# Altium schematic sheet P09_LEDS_BUTTONS_JUMPER.SchDoc(P09_LEDS_BUTTONS_JUMPER)
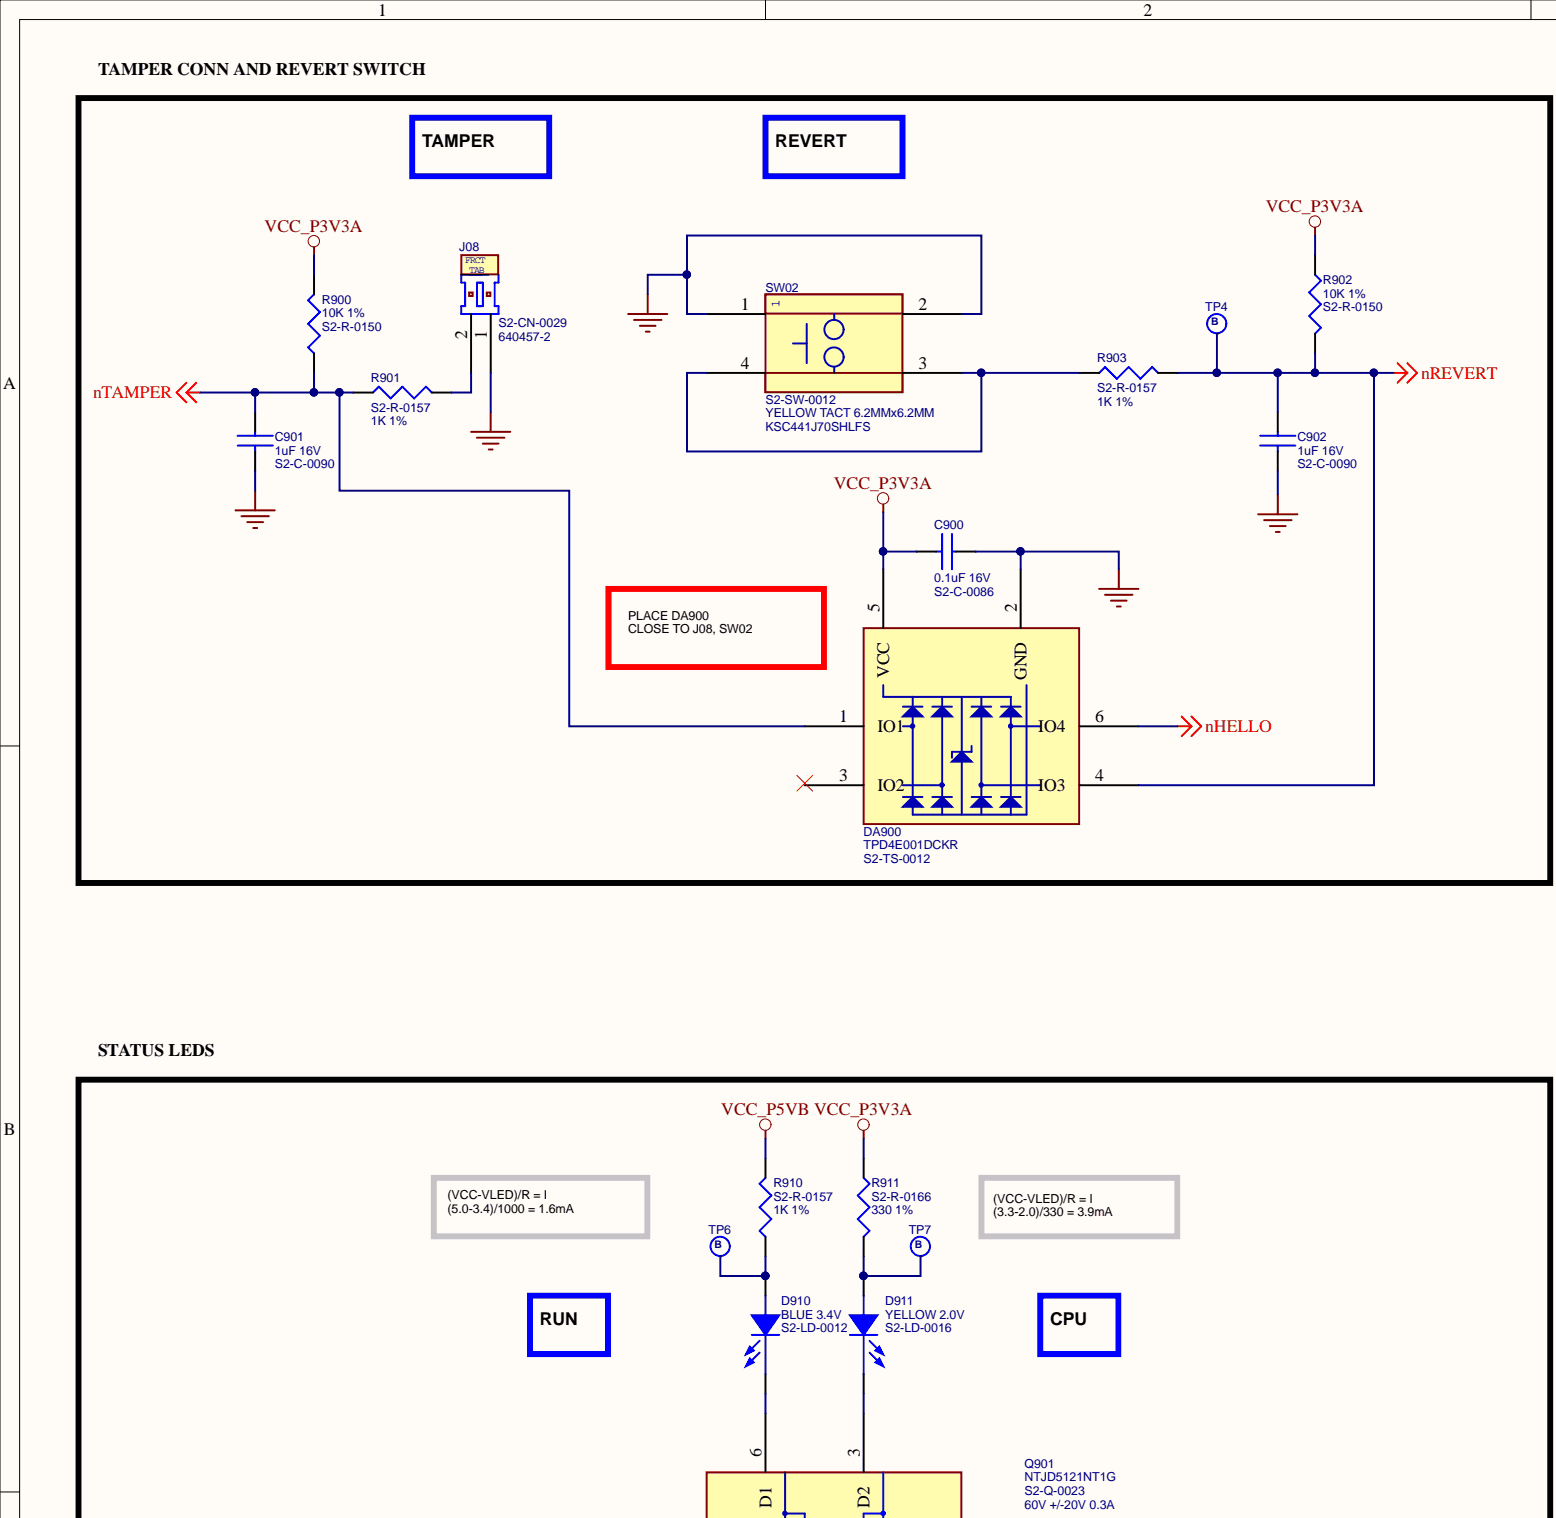
[diagram p0: P09_LEDS_BUTTONS_JUMPER.SchDoc, top left]
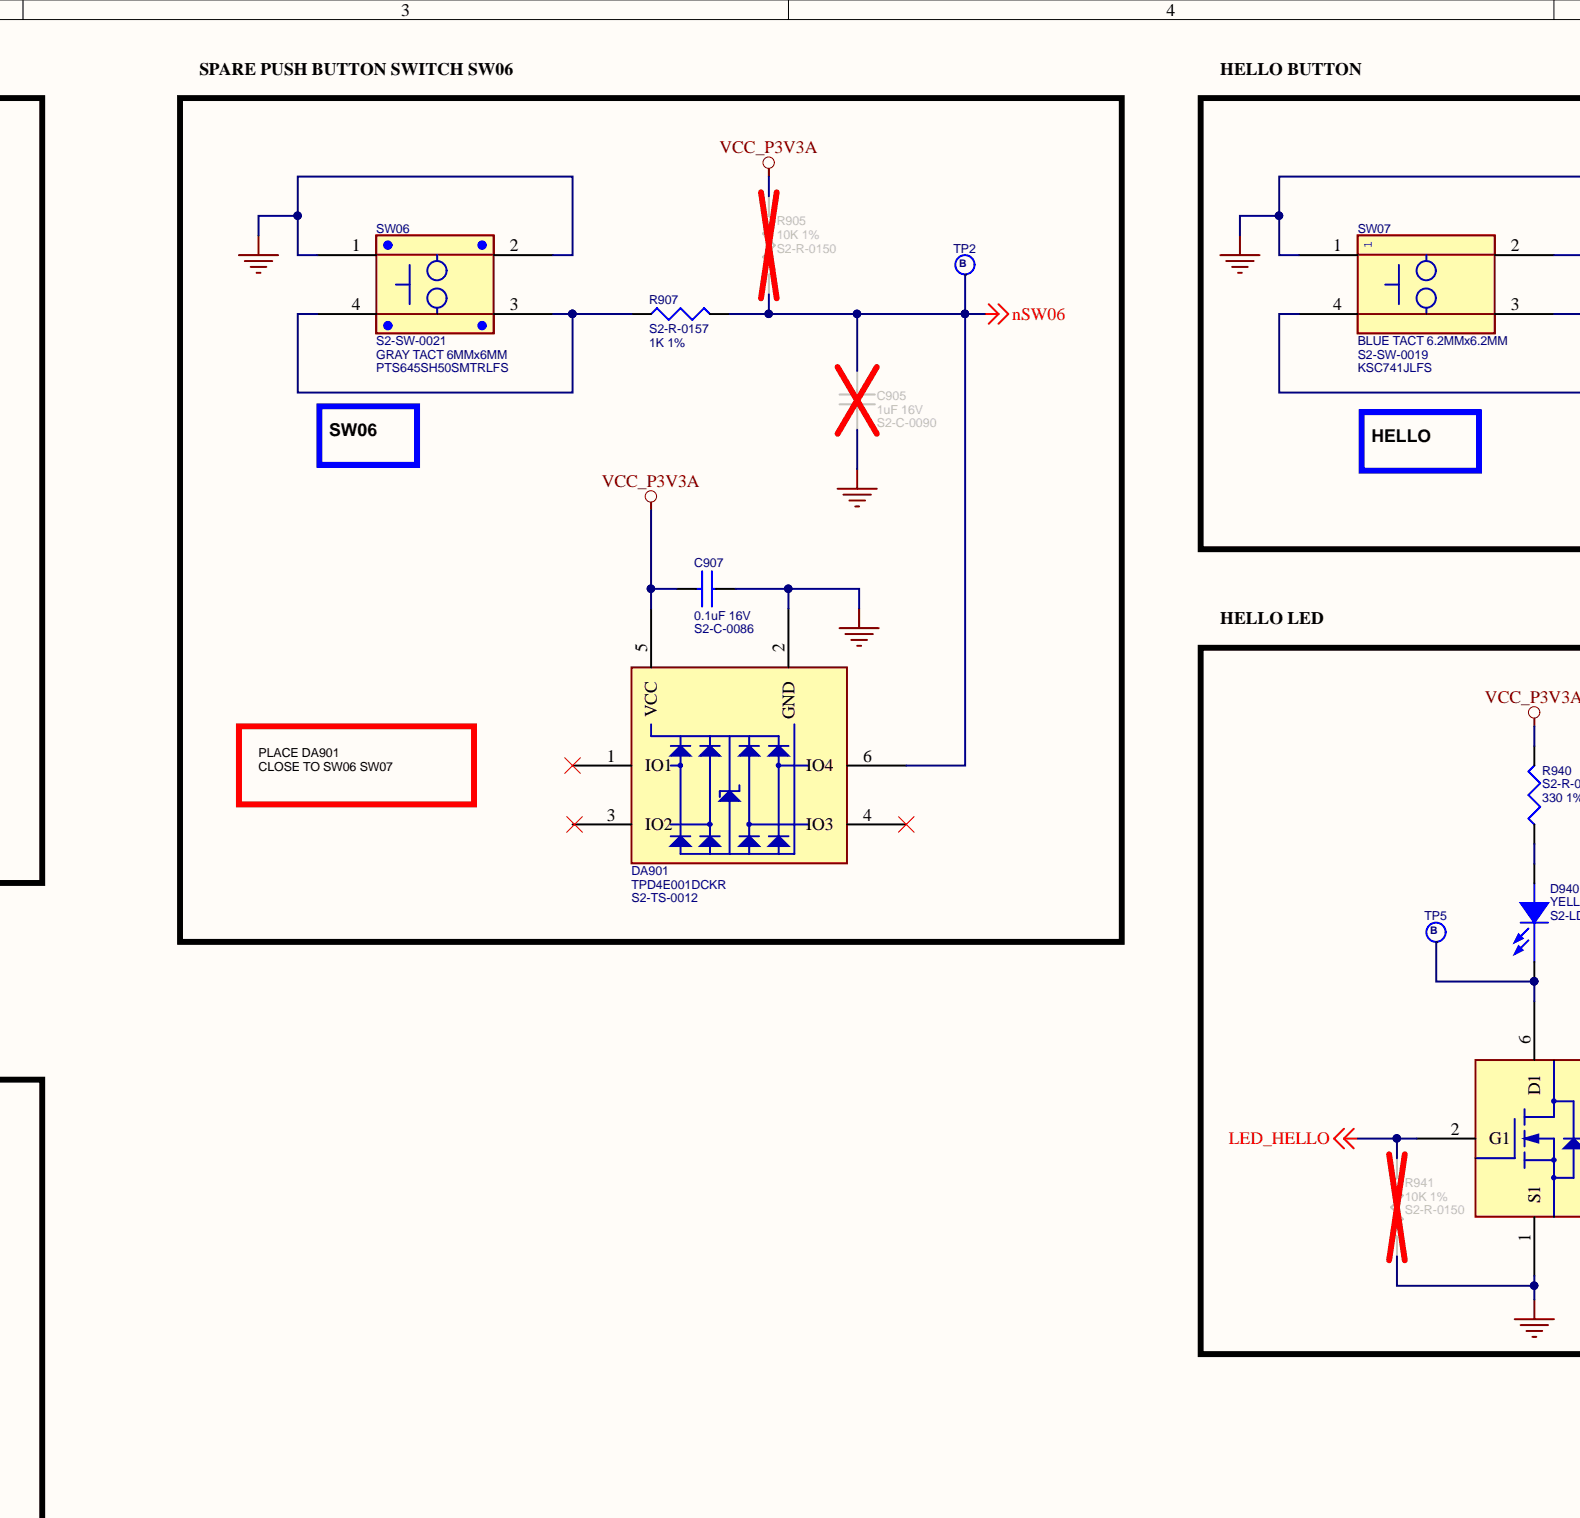
[diagram p1: P09_LEDS_BUTTONS_JUMPER.SchDoc, top centre]
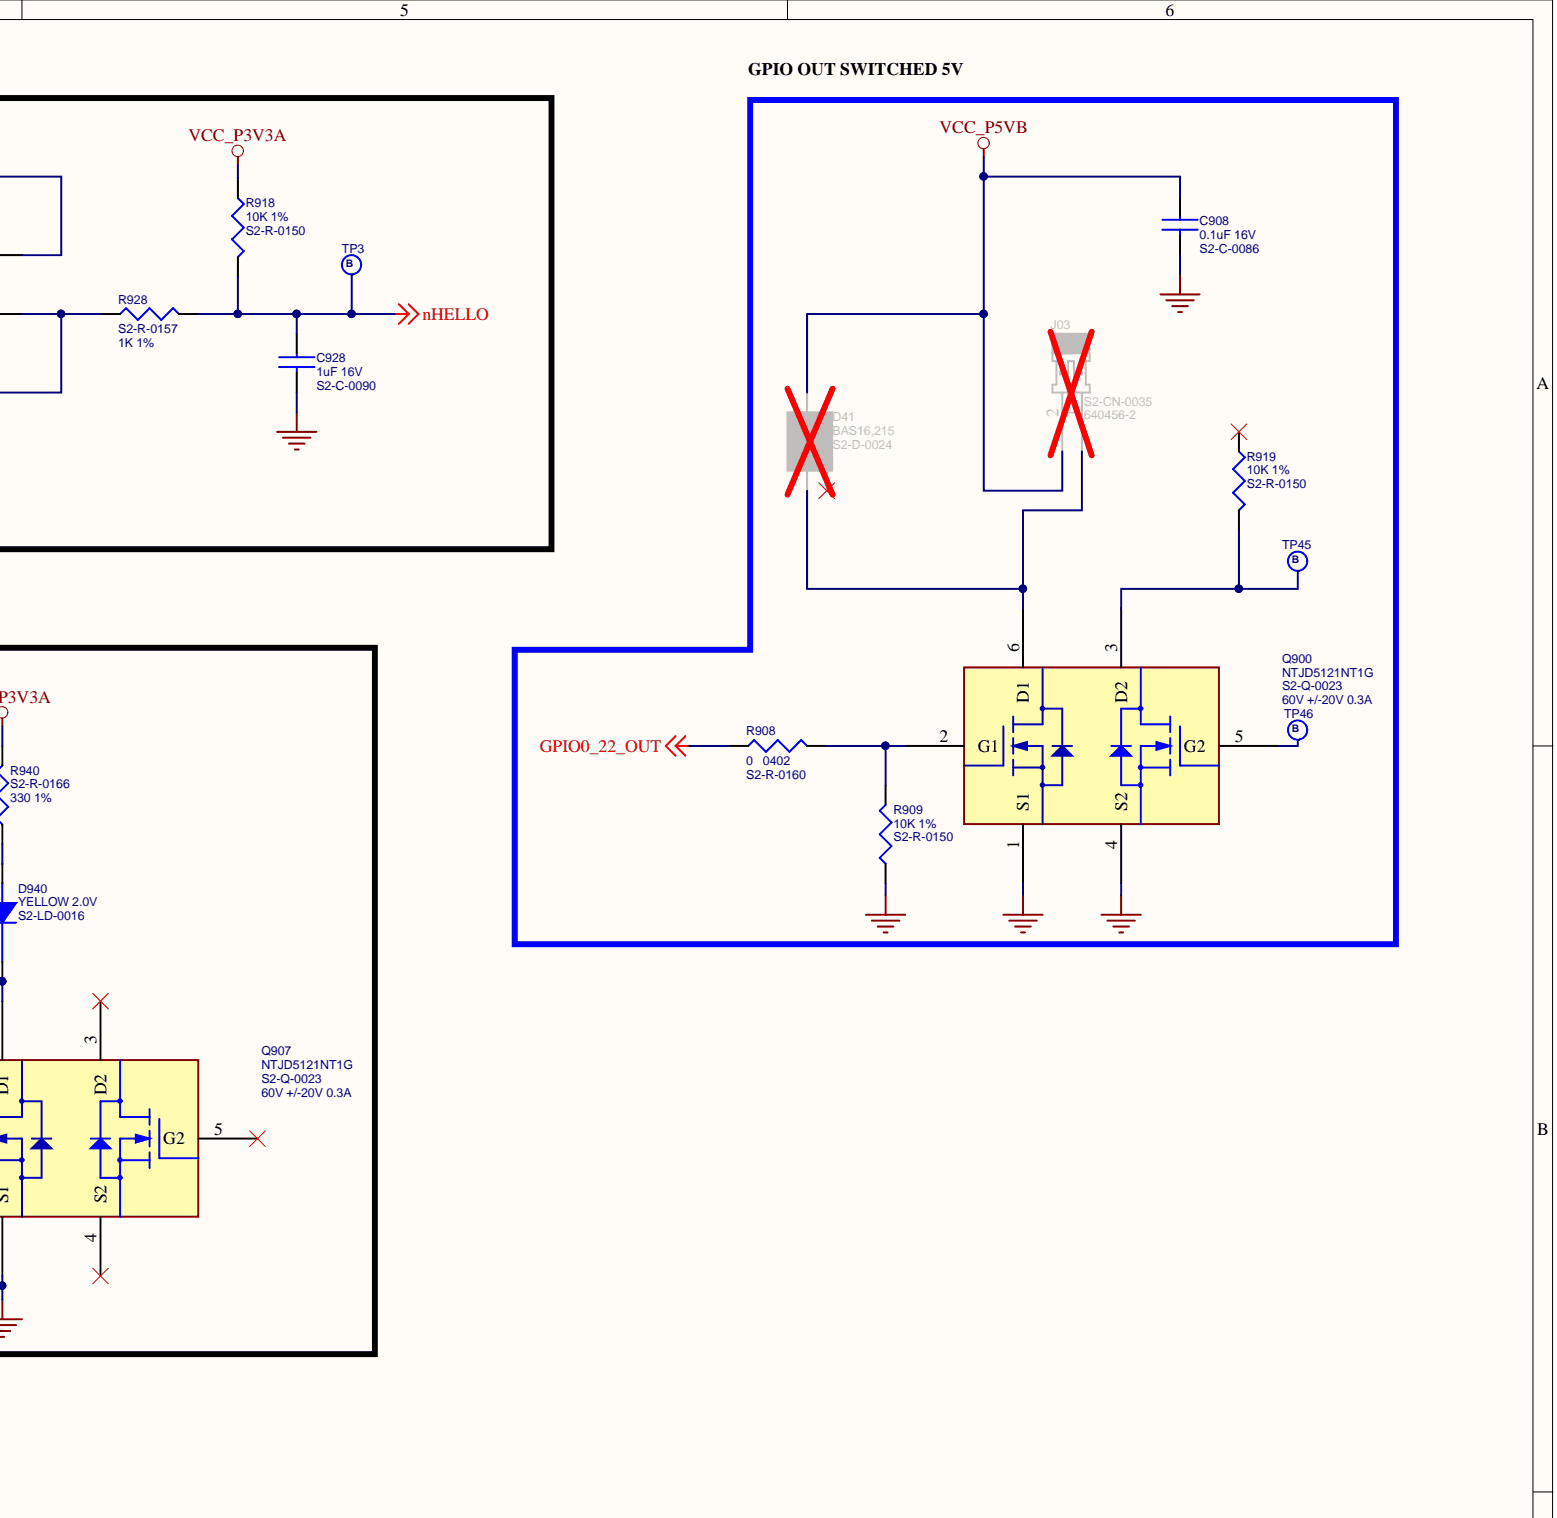
[diagram p2: P09_LEDS_BUTTONS_JUMPER.SchDoc, top right]
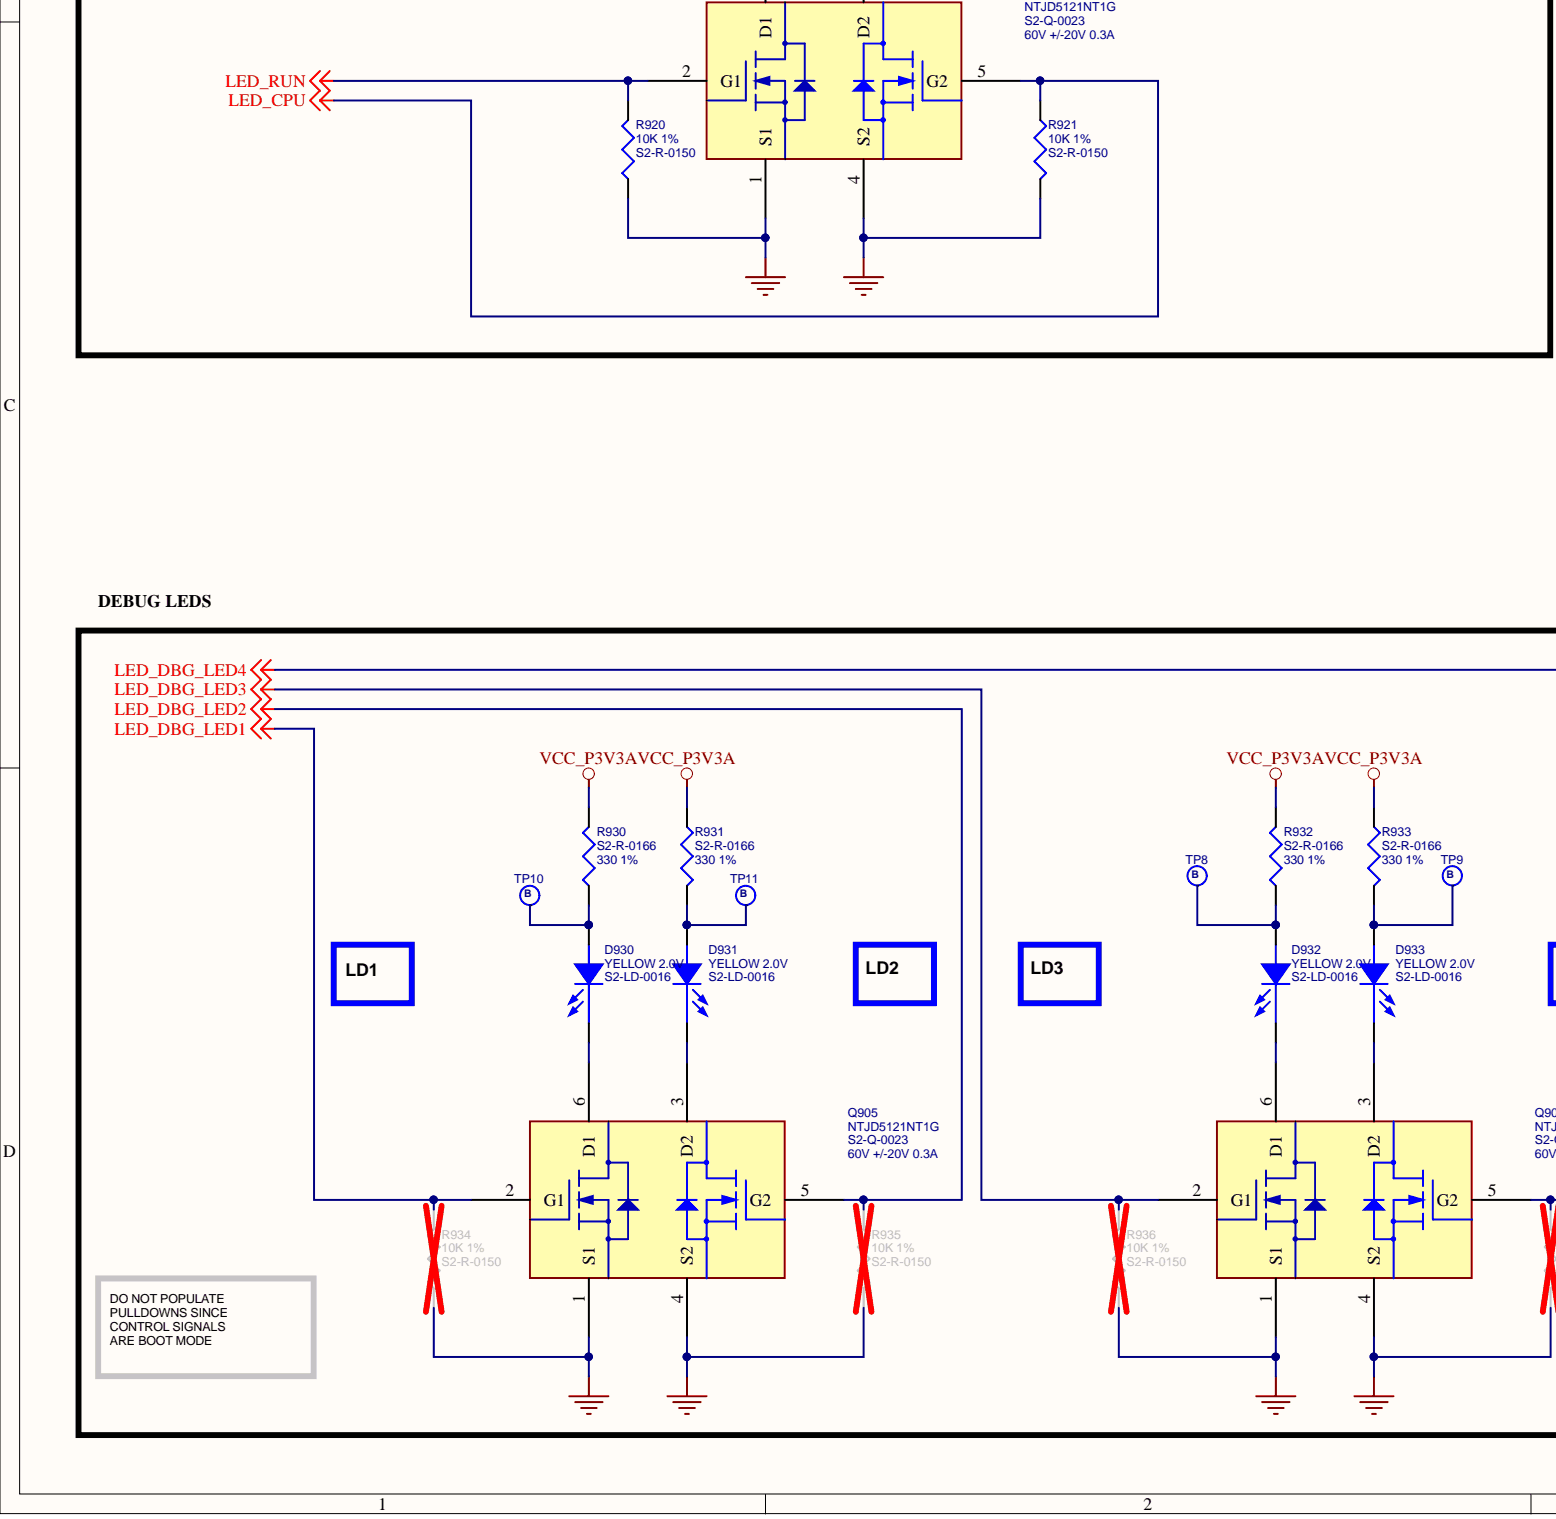
[diagram p3: P09_LEDS_BUTTONS_JUMPER.SchDoc, bottom left]
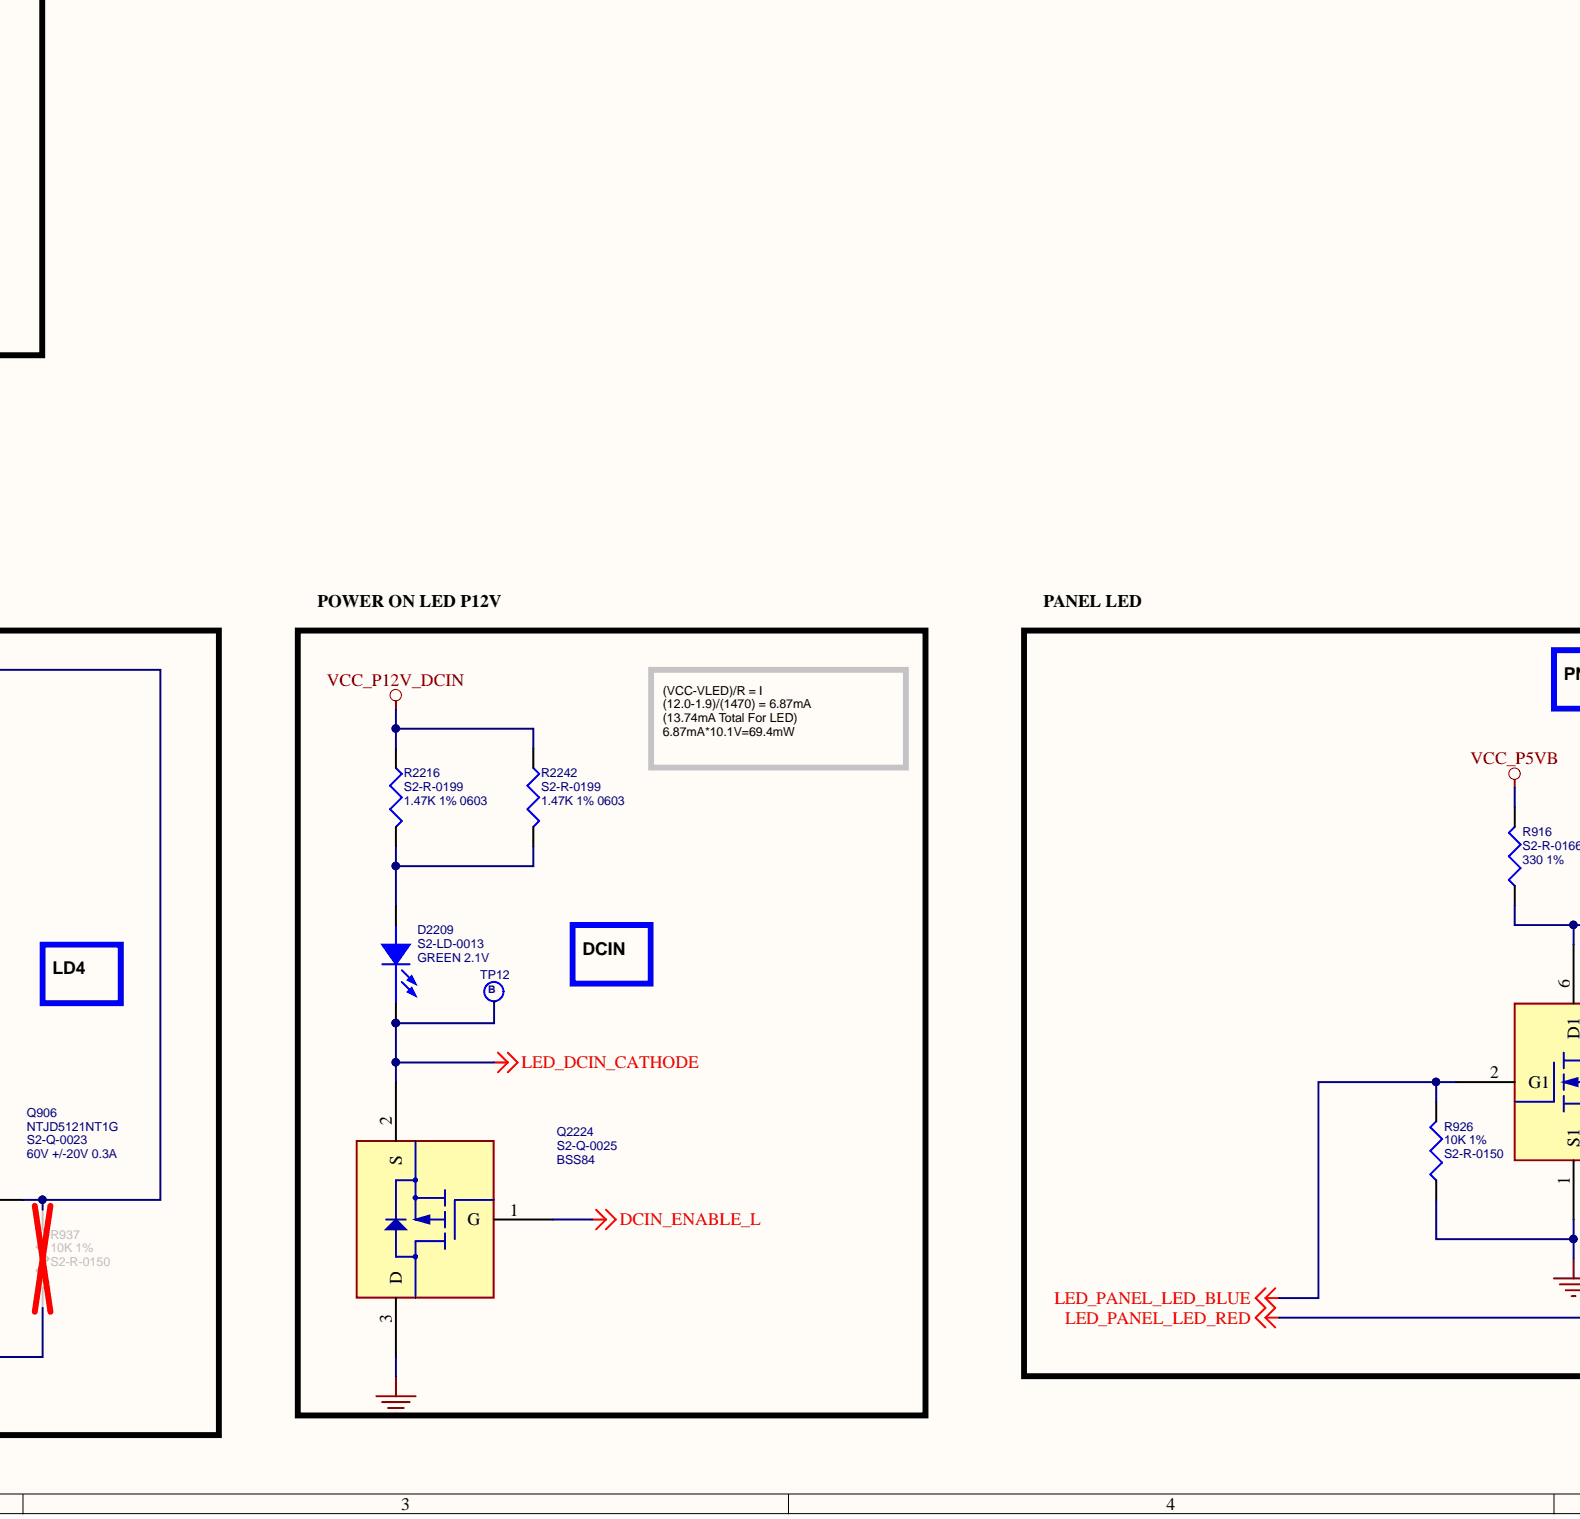
[diagram p4: P09_LEDS_BUTTONS_JUMPER.SchDoc, bottom centre]
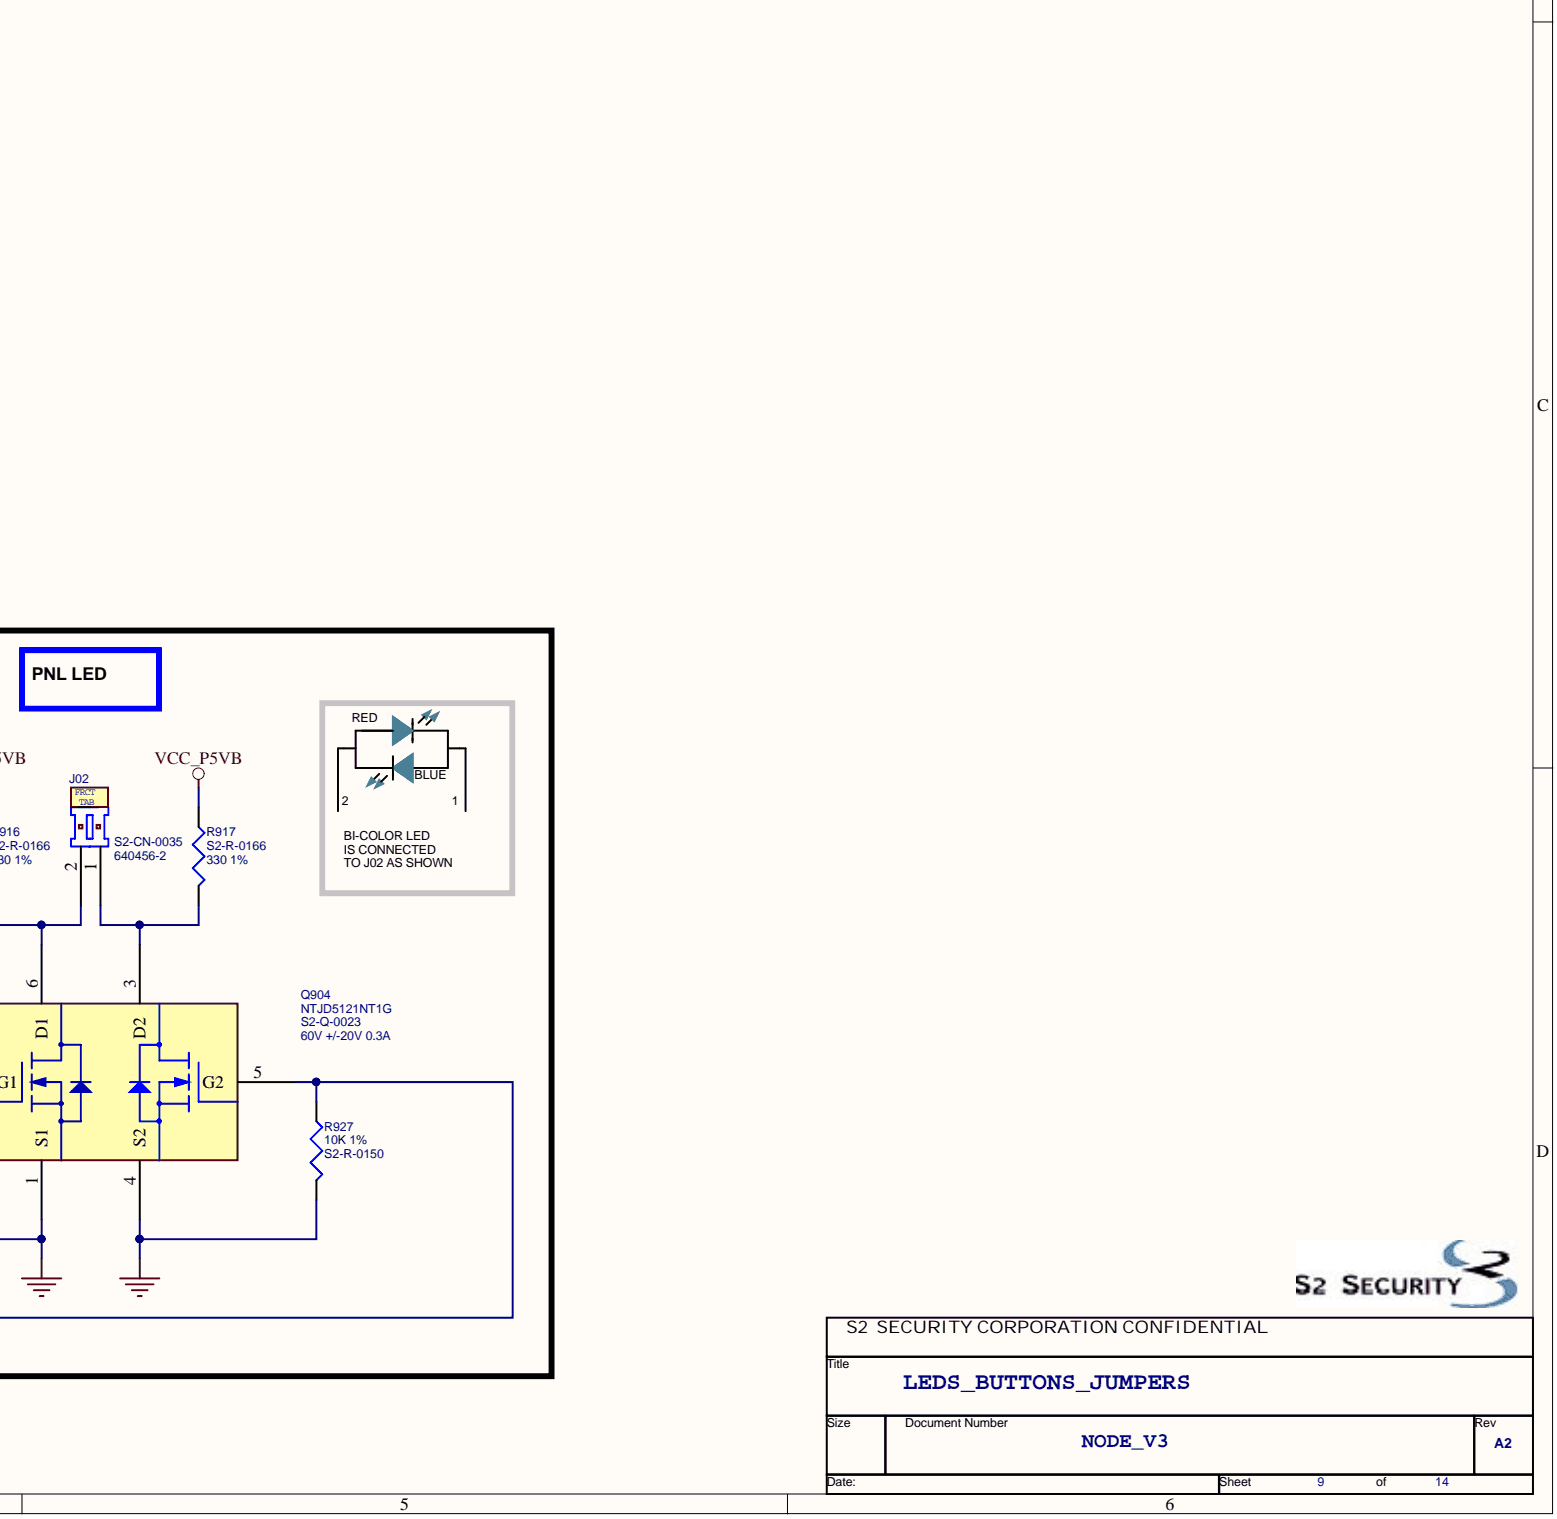
[diagram p5: P09_LEDS_BUTTONS_JUMPER.SchDoc, bottom right]

## Parts
C900  DESC: 0.1uF 16V  [CAPC1005X55N]  (hidden comment: C_0U1_16V_0402)
C901  S2_PN: S2-C-0090  DESC: 1uF 16V  [CAPC1005X55N]  (hidden comment: C_1U_16V_0402)
C902  S2_PN: S2-C-0090  DESC: 1uF 16V  [CAPC1005X55N]  (hidden comment: C_1U_16V_0402)
C905  S2_PN: S2-C-0090  DESC: 1uF 16V  [CAPC1005X55N]  (hidden comment: C_1U_16V_0402)
C907  DESC: 0.1uF 16V  [CAPC1005X55N]  (hidden comment: C_0U1_16V_0402)
C908  S2_PN: S2-C-0086  DESC: 0.1uF 16V  [CAPC1005X55N]  (hidden comment: C_0U1_16V_0402)
C928  S2_PN: S2-C-0090  DESC: 1uF 16V  [CAPC1005X55N]  (hidden comment: C_1U_16V_0402)
D41  S2_PN: S2-D-0024  DESC: BAS16,215  [SOT95P310X140-3N]  (hidden comment: D_BAS16_SOT23)
D910  S2_PN: S2-LD-0012  ATTR: BLUE 3.4V  [LED_0805]  (hidden comment: LED_0805_BLUE)
D911  S2_PN: S2-LD-0016  ATTR: YELLOW 2.0V  [LED_0805]  (hidden comment: LED_0805_YELLOW)
D930  S2_PN: S2-LD-0016  [LED_0805]  (hidden comment: LED_0805_YELLOW)
D931  S2_PN: S2-LD-0016  ATTR: YELLOW 2.0V  [LED_0805]  (hidden comment: LED_0805_YELLOW)
D932  S2_PN: S2-LD-0016  [LED_0805]  (hidden comment: LED_0805_YELLOW)
D933  S2_PN: S2-LD-0016  ATTR: YELLOW 2.0V  [LED_0805]  (hidden comment: LED_0805_YELLOW)
D940  S2_PN: S2-LD-0016  ATTR: YELLOW 2.0V  [LED_0805]  (hidden comment: LED_0805_YELLOW)
D2209  S2_PN: S2-LD-0013  [LED_0805]  (hidden comment: LED_0805_GREEN)
DA900  S2_PN: S2-TS-0012  DESC: TPD4E001DCKR  [SOIC65Px210X110P-6N]  (hidden comment: DA_TPD4E001_ESD_QUAD)
DA901  S2_PN: S2-TS-0012  DESC: TPD4E001DCKR  [SOIC65Px210X110P-6N]  (hidden comment: DA_TPD4E001_ESD_QUAD)
J02  S2_PN: S2-CN-0035  PKG: 640456-2  [Conn_640456-2]  (hidden comment: CN_100_2POS_STR_FRICT_LOCK)
J03  S2_PN: S2-CN-0035  PKG: 640456-2  [Conn_640456-2]  (hidden comment: CN_100_2POS_STR_FRICT_LOCK)
J08  S2_PN: S2-CN-0029  PKG: 640457-2  [CONN_640457-2]  (hidden comment: CN_100_2POS_RTA_FRICT_LOCK)
Q900  S2_PN: S2-Q-0023  DESC: NTJD5121NT1G  [SOT65x210x140x110-6N]  (hidden comment: Q_DUAL_NFET_NTJD5121N)
Q901  S2_PN: S2-Q-0023  DESC: NTJD5121NT1G  ATTR2: 60V +/-20V 0.3A  [SOT65x210x140x110-6N]  (hidden comment: Q_DUAL_NFET_NTJD5121N)
Q904  S2_PN: S2-Q-0023  DESC: NTJD5121NT1G  ATTR2: 60V +/-20V 0.3A  [SOT65x210x140x110-6N]  (hidden comment: Q_DUAL_NFET_NTJD5121N)
Q905  S2_PN: S2-Q-0023  DESC: NTJD5121NT1G  ATTR2: 60V +/-20V 0.3A  [SOT65x210x140x110-6N]  (hidden comment: Q_DUAL_NFET_NTJD5121N)
Q906  S2_PN: S2-Q-0023  DESC: NTJD5121NT1G  ATTR2: 60V +/-20V 0.3A  [SOT65x210x140x110-6N]  (hidden comment: Q_DUAL_NFET_NTJD5121N)
Q907  S2_PN: S2-Q-0023  DESC: NTJD5121NT1G  ATTR2: 60V +/-20V 0.3A  [SOT65x210x140x110-6N]  (hidden comment: Q_DUAL_NFET_NTJD5121N)
Q2224  S2_PN: S2-Q-0025  DESC: BSS84  [SOT95P310X140-3N]  (hidden comment: Q_PFET_BSS84)
R900  S2_PN: S2-R-0150  DESC: 10K 1%  [RESC1005X40N]  (hidden comment: R_10K_0402)
R901  S2_PN: S2-R-0157  DESC: 1K 1%  [RESC1005X40N]  (hidden comment: R_1K_0402)
R902  S2_PN: S2-R-0150  DESC: 10K 1%  [RESC1005X40N]  (hidden comment: R_10K_0402)
R903  S2_PN: S2-R-0157  DESC: 1K 1%  [RESC1005X40N]  (hidden comment: R_1K_0402)
R905  S2_PN: S2-R-0150  DESC: 10K 1%  [RESC1005X40N]  (hidden comment: R_10K_0402)
R907  S2_PN: S2-R-0157  DESC: 1K 1%  [RESC1005X40N]  (hidden comment: R_1K_0402)
R908  S2_PN: S2-R-0160  DESC: 0   0402  [RESC1005X40N]  (hidden comment: R_0_0402)
R909  S2_PN: S2-R-0150  DESC: 10K 1%  [RESC1005X40N]  (hidden comment: R_10K_0402)
R910  S2_PN: S2-R-0157  DESC: 1K 1%  [RESC1005X40N]  (hidden comment: R_1K_0402)
R911  S2_PN: S2-R-0166  [RESC1005X40N]  (hidden comment: R_330_0402)
R916  S2_PN: S2-R-0166  DESC: 330 1%  [RESC1005X40N]  (hidden comment: R_330_0402)
R917  S2_PN: S2-R-0166  DESC: 330 1%  [RESC1005X40N]  (hidden comment: R_330_0402)
R918  S2_PN: S2-R-0150  DESC: 10K 1%  [RESC1005X40N]  (hidden comment: R_10K_0402)
R919  S2_PN: S2-R-0150  DESC: 10K 1%  [RESC1005X40N]  (hidden comment: R_10K_0402)
R920  S2_PN: S2-R-0150  DESC: 10K 1%  [RESC1005X40N]  (hidden comment: R_10K_0402)
R921  S2_PN: S2-R-0150  DESC: 10K 1%  [RESC1005X40N]  (hidden comment: R_10K_0402)
R926  S2_PN: S2-R-0150  DESC: 10K 1%  [RESC1005X40N]  (hidden comment: R_10K_0402)
R927  S2_PN: S2-R-0150  DESC: 10K 1%  [RESC1005X40N]  (hidden comment: R_10K_0402)
R928  S2_PN: S2-R-0157  DESC: 1K 1%  [RESC1005X40N]  (hidden comment: R_1K_0402)
R930  S2_PN: S2-R-0166  DESC: 330 1%  [RESC1005X40N]  (hidden comment: R_330_0402)
R931  S2_PN: S2-R-0166  [RESC1005X40N]  (hidden comment: R_330_0402)
R932  S2_PN: S2-R-0166  DESC: 330 1%  [RESC1005X40N]  (hidden comment: R_330_0402)
R933  S2_PN: S2-R-0166  DESC: 330 1%  [RESC1005X40N]  (hidden comment: R_330_0402)
R934  S2_PN: S2-R-0150  DESC: 10K 1%  [RESC1005X40N]  (hidden comment: R_10K_0402)
R935  S2_PN: S2-R-0150  DESC: 10K 1%  [RESC1005X40N]  (hidden comment: R_10K_0402)
R936  S2_PN: S2-R-0150  DESC: 10K 1%  [RESC1005X40N]  (hidden comment: R_10K_0402)
R937  S2_PN: S2-R-0150  DESC: 10K 1%  [RESC1005X40N]  (hidden comment: R_10K_0402)
R940  S2_PN: S2-R-0166  DESC: 330 1%  [RESC1005X40N]  (hidden comment: R_330_0402)
R941  S2_PN: S2-R-0150  DESC: 10K 1%  [RESC1005X40N]  (hidden comment: R_10K_0402)
R2216  S2_PN: S2-R-0199  DESC: 1.47K 1% 0603  [RESC1608X55N]  (hidden comment: R_1K47_0603)
R2242  S2_PN: S2-R-0199  DESC: 1.47K 1% 0603  [RESC1608X55N]  (hidden comment: R_1K47_0603)
SW02  S2_PN: S2-SW-0012  DESC: KSC441J70SHLFS  ATTR: YELLOW TACT 6.2MMx6.2MM  [SW_KSC441J70SHLFS]  (hidden comment: SW_KSC441J_YELLOW_SMT)
SW06  S2_PN: S2-SW-0021  ATTR2: PTS645SH50SMTRLFS  ATTR: GRAY TACT 6MMx6MM  [SW_PTS645SK43SMTRLFS]  (hidden comment: SW_PTS645_SMT_GRAY)
SW07  S2_PN: S2-SW-0019  ATTR2: KSC741JLFS  ATTR: BLUE TACT 6.2MMx6.2MM  [SW_KSC441J70SHLFS]  (hidden comment: SW_KSCJ_SMT_BLUE)
TP2  TP_SMT_TEST_POINT_BOTTOM  [TP35_SMT]  (value not drawn)
TP3  TP_SMT_TEST_POINT_BOTTOM  [TP35_SMT]  (value not drawn)
TP4  TP_SMT_TEST_POINT_BOTTOM  [TP35_SMT]  (value not drawn)
TP5  TP_SMT_TEST_POINT_BOTTOM  [TP35_SMT]  (value not drawn)
TP6  TP_SMT_TEST_POINT_BOTTOM  [TP35_SMT]  (value not drawn)
TP7  TP_SMT_TEST_POINT_BOTTOM  [TP35_SMT]  (value not drawn)
TP8  TP_SMT_TEST_POINT_BOTTOM  [TP35_SMT]  (value not drawn)
TP9  TP_SMT_TEST_POINT_BOTTOM  [TP35_SMT]  (value not drawn)
TP10  TP_SMT_TEST_POINT_BOTTOM  [TP35_SMT]  (value not drawn)
TP11  TP_SMT_TEST_POINT_BOTTOM  [TP35_SMT]  (value not drawn)
TP12  TP_SMT_TEST_POINT_BOTTOM  [TP35_SMT]  (value not drawn)
TP45  TP_SMT_TEST_POINT_BOTTOM  [TP35_SMT]  (value not drawn)
TP46  TP_SMT_TEST_POINT_BOTTOM  [TP35_SMT]  (value not drawn)

## Nets
DCIN_ENABLE_L: Q2224.1
GND: C900.1 C901.2 C902.2 C905.2 C907.1 C908.2 C928.2 DA900.2(GND) DA901.2(GND) J08.1 Q900.1(S1) Q900.4(S2) Q901.1(S1) Q901.4(S2) Q904.1(S1) Q904.4(S2) Q905.1(S1) Q905.4(S2) Q906.1(S1) Q906.4(S2) Q907.1(S1) Q2224.3 R909.2 R920.2 R921.2 R926.2 R927.2 R934.2 R935.2 R936.2 R937.2 R941.2 SW02.1 SW02.2 SW06.1 SW06.2 SW07.1 SW07.2
GPIO0_22_OUT: R908.1
LED_CPU: Q901.5(G2) R921.1
LED_DBG_LED1: Q905.2(G1) R934.1
LED_DBG_LED2: Q905.5(G2) R935.1
LED_DBG_LED3: Q906.2(G1) R936.1
LED_DBG_LED4: Q906.5(G2) R937.1
LED_DCIN_CATHODE: D2209.2 Q2224.2 TP12.1
LED_HELLO: Q907.2(G1) R941.1
LED_PANEL_LED_BLUE: Q904.2(G1) R926.1
LED_PANEL_LED_RED: Q904.5(G2) R927.1
LED_RUN: Q901.2(G1) R920.1
nHELLO: C928.1 DA900.6(IO4) R918.2 R928.2 TP3.1
nREVERT: C902.1 DA900.4(IO3) R902.2 R903.2 TP4.1
nSW06: C905.1 DA901.6(IO4) R905.2 R907.2 TP2.1
nTAMPER: C901.1 DA900.1(IO1) R900.2 R901.1
VCC_P3V3A: C900.2 C907.2 DA900.5(VCC) DA901.5(VCC) R900.1 R902.1 R905.1 R911.1 R918.1 R930.1 R931.1 R932.1 R933.1 R940.1
VCC_P5VB: C908.1 D41.3 J03.2 R910.1 R916.1 R917.1
VCC_P12V_DCIN: R2216.1 R2242.1
NetD41_1: D41.1 J03.1 Q900.6(D1)
NetD910_1: D910.1 R910.2 TP6.1
NetD910_2: D910.2 Q901.6(D1)
NetD911_1: D911.1 R911.2 TP7.1
NetD911_2: D911.2 Q901.3(D2)
NetD930_1: D930.1 R930.2 TP10.1
NetD930_2: D930.2 Q905.6(D1)
NetD931_1: D931.1 R931.2 TP11.1
NetD931_2: D931.2 Q905.3(D2)
NetD932_1: D932.1 R932.2 TP8.1
NetD932_2: D932.2 Q906.6(D1)
NetD933_1: D933.1 R933.2 TP9.1
NetD933_2: D933.2 Q906.3(D2)
NetD940_1: D940.1 R940.2
NetD940_2: D940.2 Q907.6(D1) TP5.1
NetD2209_1: D2209.1 R2216.2 R2242.2
NetJ02_1: J02.1 Q904.3(D2) R917.2
NetJ02_2: J02.2 Q904.6(D1) R916.2
NetJ08_2: J08.2 R901.2
NetQ900_2: Q900.2(G1) R908.2 R909.1
NetQ900_3: Q900.3(D2) R919.2 TP45.1
NetQ900_5: Q900.5(G2) TP46.1
NetR903_1: R903.1 SW02.3 SW02.4
NetR907_1: R907.1 SW06.3 SW06.4
NetR928_1: R928.1 SW07.3 SW07.4

Not connected: D41 2; DA900 3(IO2); DA901 1(IO1) 3(IO2) 4(IO3); Q907 3(D2) 4(S2) 5(G2); R919 1

## Library data of the parts
C900 C907 = C_0U1_16V_0402: S2_PN: S2-C-0086; PKG: CC0402IPC; ATTR: X7R 10%; Library Name: NODE_V2_M1.SCHLIB; Component Kind: Standard
C901 C902 C905 C928 = C_1U_16V_0402: PKG: CC0402IPC; ATTR: X5R 10%; Library Name: NODE_V2_M1.SCHLIB; Component Kind: Standard
C908 = C_0U1_16V_0402: PKG: CC0402IPC; ATTR: X7R 10%; Library Name: NODE_V2_M1.SCHLIB; Component Kind: Standard
D41 = D_BAS16_SOT23: PKG: SOT-23-3; ATTR: DIODE SWITCHING 500NA REVERSE LEAKAGE SOT-23-3; Library Name: NODE_V2_M1.SCHLIB; Component Kind: Standard
D910 = LED_0805_BLUE: DESC: LED 0805 BLUE 3.4V 30MCD; PKG: LED_0805; Library Name: NODE_V2_M1.SCHLIB; Component Kind: Standard
D911 D931 D933 D940 = LED_0805_YELLOW: DESC: LED 0805 YELLOW 2V 50MCD; PKG: LED_0805; Library Name: NODE_V2_M1.SCHLIB; Component Kind: Standard
D930 D932 = LED_0805_YELLOW: DESC: LED 0805 YELLOW 2V 50MCD; ATTR: YELLOW 2.0V; PKG: LED_0805; Library Name: NODE_V2_M1.SCHLIB; Component Kind: Standard
D2209 = LED_0805_GREEN: DESC: LED 0805 GREEN 2.1V 12MCD; ATTR: GREEN 2.1V; PKG: LED_0805; Library Name: NODE_V2_M1.SCHLIB; Component Kind: Standard
DA900 DA901 = DA_TPD4E001_ESD_QUAD: PKG: SC-70-6; ATTR: ESD PROTECTION QUAD LOW VOLT LOW VAP; Library Name: NODE_V2_M1.SCHLIB; Component Kind: Standard
J02 J03 = CN_100_2POS_STR_FRICT_LOCK: ATTR: HEADER MALE 2POS 0.100" STR FRICTION LOCK; Library Name: NODE_V2_M1.SCHLIB; DESC: 640456-2; Component Kind: Standard
J08 = CN_100_2POS_RTA_FRICT_LOCK: ATTR: HEADER MALE 2POS 0.100" RTA FRICTION LOCK; Library Name: NODE_V2_M1.SCHLIB; DESC: 640457-2; Component Kind: Standard
Q900 = Q_DUAL_NFET_NTJD5121N: PKG: SC-88; ATTR2: 60V +/-20V 0.3A; ATTR: MOSFET DUAL N-CHAN 60V +/-20V 0.3A; Library Name: NODE_V2_M1.SCHLIB; Component Kind: Standard
Q901 Q904-Q907 = Q_DUAL_NFET_NTJD5121N: PKG: SC-88; ATTR: MOSFET DUAL N-CHAN 60V +/-20V 0.3A; Library Name: NODE_V2_M1.SCHLIB; Component Kind: Standard
Q2224 = Q_PFET_BSS84: PKG: SOT-23; ATTR2: -50V 0.13A 10OHM; ATTR: MOSFET P-CH 10 OHM -50V 0.13A SOT-23; Library Name: NODE_V2_M1.SCHLIB; Component Kind: Standard
R900 R902 R905 R909 R918-R921 R926 R927 R934-R937 R941 = R_10K_0402: PKG: CC0402IPC; ATTR: 1/16W; Library Name: NODE_V2_M1.SCHLIB; Component Kind: Standard
R901 R903 R907 R910 R928 = R_1K_0402: PKG: CC0402IPC; ATTR: 1/16W; Library Name: NODE_V2_M1.SCHLIB; Component Kind: Standard
R908 = R_0_0402: PKG: CC0402IPC; ATTR: 1/16W  50mohm MAX; Library Name: NODE_V2_M1.SCHLIB; Component Kind: Standard
R911 R931 = R_330_0402: PKG: CC0402IPC; DESC: 330 1%; ATTR: 1/16W; Library Name: NODE_V2_M1.SCHLIB; Component Kind: Standard
R916 R917 R930 R932 R933 R940 = R_330_0402: PKG: CC0402IPC; ATTR: 1/16W; Library Name: NODE_V2_M1.SCHLIB; Component Kind: Standard
R2216 R2242 = R_1K47_0603: PKG: CC0603IPC; ATTR: RES 1.47K OHM 1/10W 1% 0603; Library Name: NODE_V2_M1.SCHLIB; Component Kind: Standard
SW02 = SW_KSC441J_YELLOW_SMT: PKG: KSC441J_SMT; ATTR2: SWITCH SMT YELLOW 6.2MMx6.2MM J-BEND; Library Name: NODE_V2_M1.SCHLIB; Component Kind: Standard
SW06 = SW_PTS645_SMT_GRAY: DESC: SWITCH SMT GRAY 6MMx6MM; PKG: PTS645_SMT; Library Name: NODE_V2_M1.SCHLIB; Component Kind: Standard
SW07 = SW_KSCJ_SMT_BLUE: PKG: KSC441J_SMT; DESC: SWITCH SMT BLUE 6.2MMx6.2MM J-BEND; Library Name: NODE_V2_M1.SCHLIB; Component Kind: Standard
TP2-TP12 TP45 TP46 = TP_SMT_TEST_POINT_BOTTOM: S2_PN: S2-TP-0003; PKG: TEST-PT-TPB; DESC: TEST POINT; ATTR: SMT BOTTOM SIDE TEST POINT; Library Name: NODE_V2_M1.SCHLIB; DNP: DNP; Component Kind: Standard
